# Altium schematic sheet ChildBoard.SchDoc
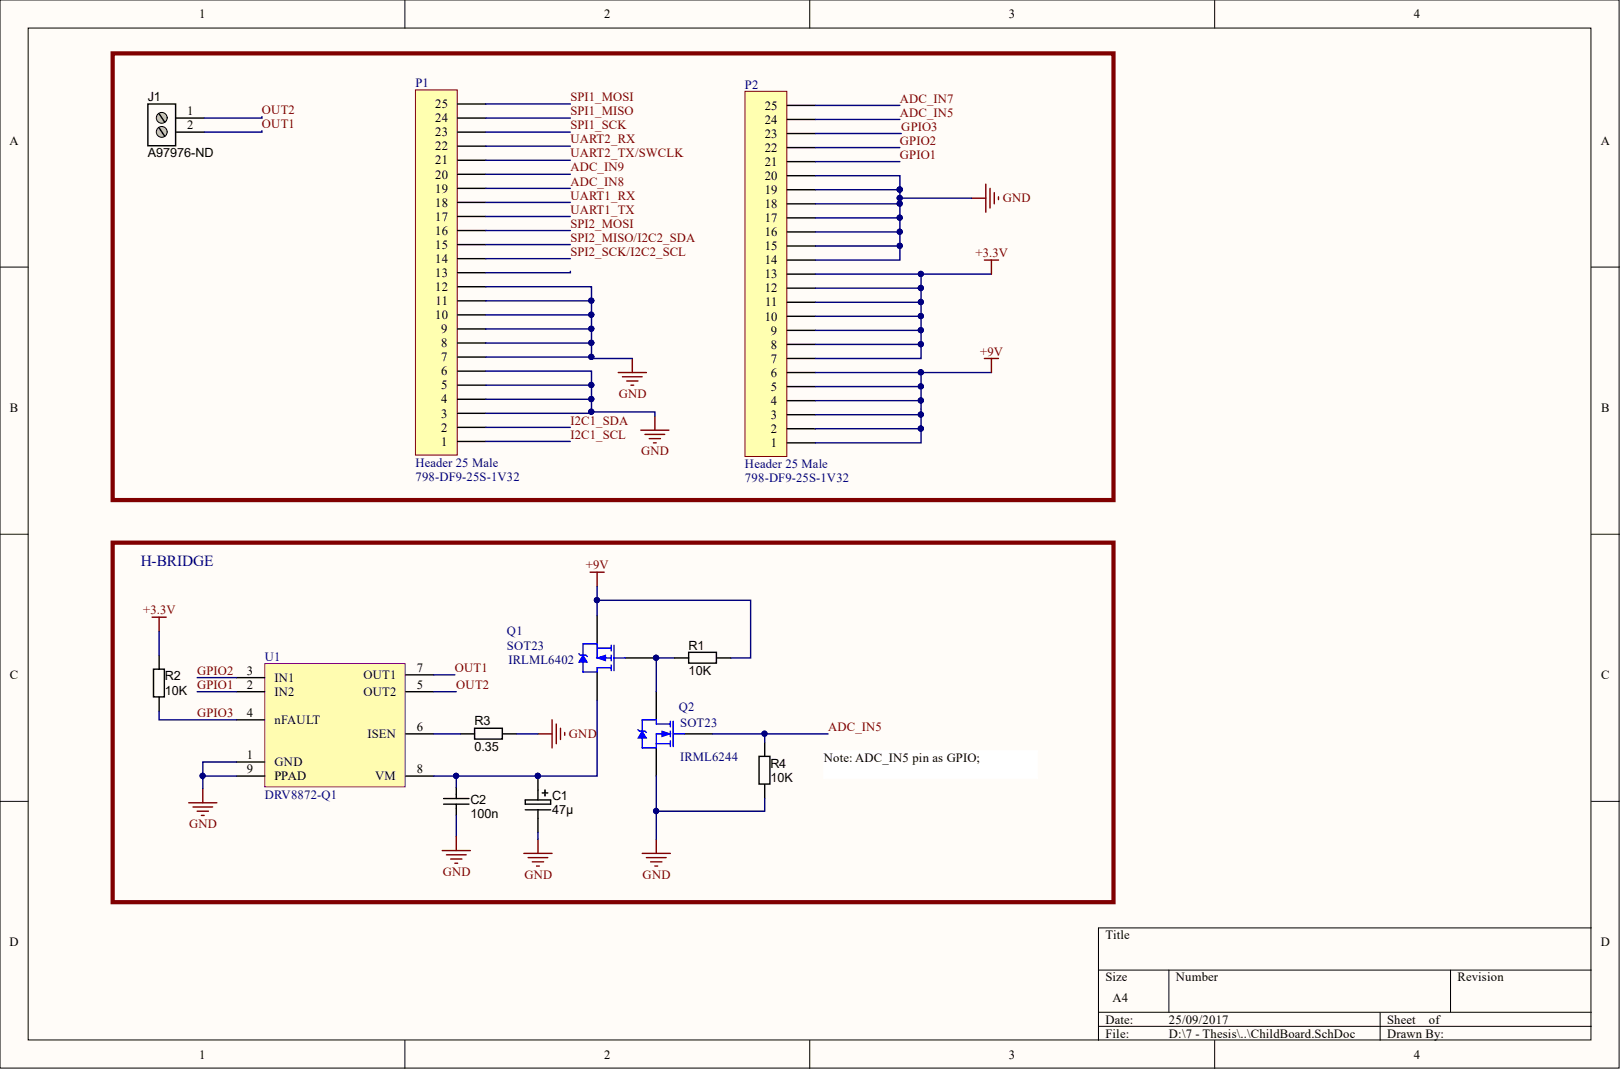
[diagram p0: ChildBoard.SchDoc]

## Parts
C1  47µ  [CAP_ELEC_Size_H13]
C2  value 100n  [CAPC1608N]  (hidden comment: approved sub OK)
J1  Supplier Part Number 1: A97976-ND  [2P_PCB_ScrewTerm_90S_3.81MM]  (hidden comment: Stackable ScrewTerm)
P1  Header 25 Male  Supplier Part Number 1: 798-DF9-25S-1V32  [DF9-25S-1V (MALE)]
P2  Header 25 Male  Supplier Part Number 1: 798-DF9-25S-1V32  [DF9-25S-1V (MALE)]
Q1  SOT23  Reference: IRLML6402  [SOT23]
Q2  SOT23  Reference: IRML6244  [SOT23]
R1  value 10K  [RESC1608N]  (hidden comment: Thick Film)
R2  value 10K  [RESC1608N]  (hidden comment: Thick Film)
R3  value 0.35  [RESC1608N]  (hidden comment: Thick Film)
R4  value 10K  [RESC1608N]  (hidden comment: Thick Film)
U1  DRV8872-Q1  [HSOP-8-DDA]

## Nets
+3.3V: P2.7 P2.8 P2.9 P2.10 P2.11 P2.12 P2.13 R2.1
+9V: P2.1 P2.2 P2.3 P2.4 P2.5 P2.6 Q1.3 R1.2
GND: C1.2 C2.1 P1.3 P1.4 P1.5 P1.6 P1.7 P1.8 P1.9 P1.10 P1.11 P1.12 P2.14 P2.15 P2.16 P2.17 P2.18 P2.19 P2.20 Q2.3 R3.2 R4.1 U1.1(GND) U1.9(PPAD)
ADC_IN5: P2.24 Q2.1 R4.2
ADC_IN7: P2.25
ADC_IN8: P1.19
ADC_IN9: P1.20
GPIO1: P2.21 U1.2(IN2)
GPIO2: P2.22 U1.3(IN1)
GPIO3: P2.23 R2.2 U1.4(nFAULT)
I2C1_SCL: P1.1
I2C1_SDA: P1.2
OUT1: J1.2 U1.7(OUT1)
OUT2: J1.1 U1.5(OUT2)
SPI1_MISO: P1.24
SPI1_MOSI: P1.25
SPI1_SCK: P1.23
SPI2_MISO/I2C2_SDA: P1.15
SPI2_MOSI: P1.16
SPI2_SCK/I2C2_SCL: P1.14
UART1_RX: P1.18
UART1_TX: P1.17
UART2_RX: P1.22
UART2_TX/SWCLK: P1.21
NetC1_1: C1.1 C2.2 Q1.2 U1.8(VM)
NetQ1_1: Q1.1 Q2.2 R1.1
NetR3_1: R3.1 U1.6(ISEN)

Not connected: P1 13

## Library data of the parts
C1 = CAPE_47u_100V_SMD: Capacitor SMD Electrolytic 47uF 20% 100V Radial; Manufacturer 1: Panasonic - ECG; Manufacturer Part Number 1: EEV-TG2A470Q; Material: Aluminum Electrolytic; Component Kind: Standard; Library Name: PBL - Capacitors.SCHLIB; Package / Case: Radial - Surface Mount; Ratings: 100V; Supplier 1: Digi-Key; Supplier Part Number 1: PCE3701CT-ND; Tolerance: ±20%; Value: 47µ
C2 = CAPC_100n_0603: Capacitor SMD Cer 100nF 10% 50V X7R 0603; Manufacturer 1: AVX Corporation; Manufacturer Part Number 1: 06035C104KAT2A; Material: ceramic; Ratings: 50V; X7R; Component Kind: Standard; ComponentLink1Description: http://www.avx.com/docs/Catalogs/cx7r.pdf; ComponentLink1URL: http://www.avx.com/docs/Catalogs/cx7r.pdf; ComponentLink2Description: http://product.tdk.com/capacitor/mlcc/en/documents/mlcc_commercial_general_en.pdf; ComponentLink2URL: http://product.tdk.com/capacitor/mlcc/en/documents/mlcc_commercial_general_en.pdf; Library Name: PBL - Capacitors.SCHLIB; Manufacturer 2: TDK Corporation; Manufacturer Part Number 2: C1608X7R1H104K; Package / Case: 0603 (1608 Metric); Supplier 1: Digi-Key; Supplier 2: Digi-Key; Supplier Part Number 1: 478-5052-1-ND; Supplier Part Number 2: 445-1314-1-ND; Tolerance: ±10%
J1 = 2P_ScrewTerm_90S_3.81MM: Terminal Block Screw Type THT 2-Position 3.81mm pitch; Manufacturer 1: Tyco Electronics; Manufacturer Part Number 1: 1776113-2; Pitch: 0.150" (3.81mm); Positions Per Level: 2; Value: 2 position; Category: Connectors Interconnects; Color: Black; Component Kind: Standard; Library Name: PBL - Connectors.SCHLIB; Package / Case: Side Entry; 3.81mm pitch; Supplier 1: Digi-Key
P1 P2 = Header 25 Male: Header, 25-Pin; Component Kind: Standard; LatestRevisionDate: 17-Jul-2002; LatestRevisionNote: Re-released for DXP Platform.; Library Name: MainBoardSch.SCHLIB; Signal Integrity: Connector; Supplier 1: Mouser; Publisher: Altium Limited
Q1 = PMOS-2: P-Channel Power MOSFET; Manufacturer Part Number 1: IRLML6402TRPBF; Component Kind: Standard; Library Name: Miscellaneous Devices.IntLib; Manufacturer 1: Manufacturer 1; Simulation: IRF9510; Supplier 1: Mouser; Supplier Part Number 1: 942-IRLML6402TRPBF; Supplier Unit Price 1: 0.332
Q2 = NMOS-2: N-Channel Power MOSFET; Note: Pin 2 is electrically connected to pin 4.; Component Kind: Standard; ComponentLink1Description: Manufacturer Link; ComponentLink1URL: http://www.irf.com/; DatasheetVersion: PD-9.814; LatestRevisionDate: 17-Jul-2002; LatestRevisionNote: Re-released for DXP Platform.; Library Name: Miscellaneous Devices.IntLib; PackageDescription: TO, Thru-Hole, Vertical, Heatsink Mounted; 3 In-Line Leads; Pitch 2.54 mm; PackageReference: TO-220AB; PackageVersion: Feb-1997; Published: 8-Jun-2000; Simulation: IRF1010; Publisher: Altium Limited
R1 R2 R4 = RES_10K_0603_5%: Resistor SMD chip 10k Ohm 0.1W 5% 0603; Manufacturer 1: Yageo; Manufacturer Part Number 1: RC0603JR-0710KL; Ratings: 1/10 W; Component Kind: Standard; Library Name: PBL - Resistors.SCHLIB; Package / Case: 0603 (1608 metric); Supplier 1: Digi-Key; Supplier Part Number 1: 311-10KGRCT-ND; Tolerance: ±5%
R3 = RES_10K_0603_5%: Resistor SMD chip 10k Ohm 0.1W 5% 0603; Manufacturer 1: Yageo; Manufacturer Part Number 1: RL0603FR-070R35L; Ratings: 1/10 W; Tolerance: ±1%; Component Kind: Standard; Library Name: PBL - Resistors.SCHLIB; Package / Case: 0603 (1608 metric); Supplier 1: Mouser; Supplier Part Number 1: 603-RL0603FR-070R35L
U1 = DRV8872-Q1: Manufacturer 1: Texas Instruments; Manufacturer Part Number 1: DRV8872DDARQ1; Component Kind: Standard; Supplier 1: Mouser; Supplier Part Number 1: 595-DRV8872DDARQ1; Supplier Unit Price 1: 2,10; Library Name: ruben_schematic.SchLib
